# Altium schematic sheet Sensor_Box_PWR_AC.SchDoc
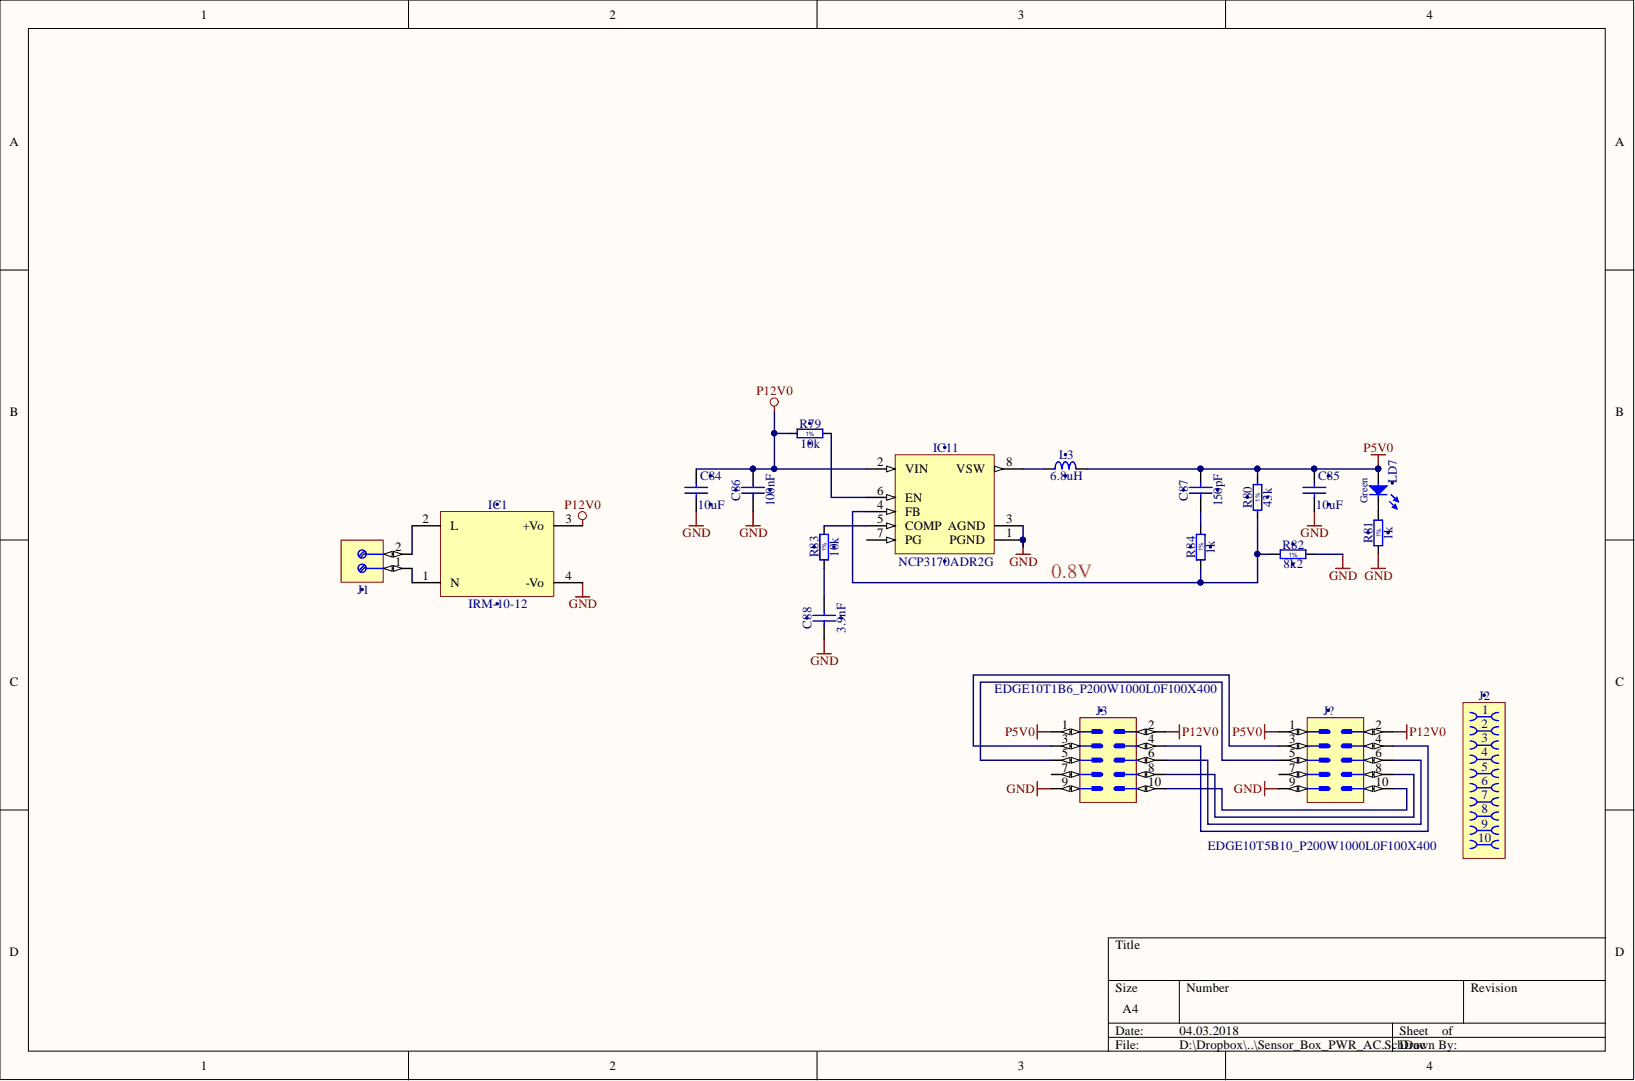
[diagram p0: Sensor_Box_PWR_AC.SchDoc]

## Parts
C84  10uF  [CAPC2012X135N]
C85  10uF  [CAPC2012X135N]
C86  100nF  [CAPC1005X55N]
C87  150pF  [CAPC1608X87N]
C88  3.9nF  [CAPC1608X87N]
IC1  IRM-10-12  [PWS_MEANWELL_IRM-10]
IC11  NCP3170ADR2G  [SOIC127P600X175-8N]
J1  Family  [PHOENIX_1751248]  (value not drawn)
J2  Family  [AVX_00 9159 010 061 916]  (value not drawn)
J3  Family  [EDGE10T1B6_P200W1000L0F100X400]  (value not drawn)  (no BOM)
J?  Family  [EDGE10T5B10_P200W1000L0F100X400]  (value not drawn)  (no BOM)
L3  6.8uH  [IND_BOURNS_SRU8043]
LD7  Green  [LED_KINGBRIGHT_KPTR3216]
R79  10k  [RESC1005X40N]
R80  43k  [RESC1608X55N]
R81  1k  [RESC1005X40N]
R82  8k2  [RESC1608X55N]
R83  10k  [RESC1005X40N]
R84  1k  [RESC1005X40N]

## Nets
GND: C84.2 C85.2 C86.1 C88.1 IC1.4(-Vo) IC11.1(PGND) IC11.3(AGND) J3.9 J?.9 R81.1 R82.2
P5V0: C85.1 C87.2 J3.1 J?.1 L3.2 LD7.2 R80.2
P12V0: C84.1 C86.2 IC1.3(+Vo) IC11.2(VIN) J3.2 J?.2 R79.1
NetC87_1: C87.1 R84.2
NetC88_2: C88.2 R83.1
NetIC1_1: IC1.1(N) J1.1
NetIC1_2: IC1.2(L) J1.2
NetIC11_4: IC11.4(FB) R80.1 R82.1 R84.1
NetIC11_5: IC11.5(COMP) R83.2
NetIC11_6: IC11.6(EN) R79.2
NetIC11_8: IC11.8(VSW) L3.1
NetJ3_3: J3.3 J?.3
NetJ3_4: J3.4 J?.4
NetJ3_5: J3.5 J?.5
NetJ3_6: J3.6 J?.6
NetJ3_8: J3.8 J?.8
NetJ3_10: J3.10 J?.10
NetLD7_1: LD7.1 R81.2

Not connected: IC11 7(PG); J3 7; J? 7

## Library data of the parts
C84 C85 = CC0805_10UF_10V_10%_X7R: Non-polarised capacitor; Manufacturer: GENERIC; Manufacturer1 Part Number: GRM21BR71A106KE51L; Part Description: SMD Multilayer Chip Ceramic Capacitor; Database Table Name: Capacitors SMD; TC: X7R; Tolerance: ±10%; Voltage: 10V; Case: 0805; Component Kind: Standard; Component Type: Standard; CreateDate: 2012-04-30; HelpURL: \\cern.ch\dfs\Applications\Altium\Datasheets\CC0805_X7R_MURATA.pdf; LatestRevisionDate: 2012-04-30; Library Name: Capacitors.DbLib; Manufacturer1 ComponentHeight: 1.35mm; Manufacturer1 Example: MURATA; Manufacturer Part Number: CC0805_10UF_10V_10%_X7R; Mounted: Yes; Part Number: CC0805_10UF_10V_10%_X7R; Pin Count: 2; SMD: Yes; Socket: No; Status: Preferred; Table: Capacitors SMD; Value: 10uF; Author: CERN DEM JLC
C86 = CC0402_100NF_16V_10%_X5R: Non-polarised capacitor; Manufacturer: GENERIC; Manufacturer1 Part Number: GRM155R61C104KA88D; Part Description: SMD Multilayer Chip Ceramic Capacitor; Database Table Name: Capacitors SMD; TC: X5R; Tolerance: ±10%; Voltage: 16V; Case: 0402; Component Kind: Standard; Component Type: Standard; CreateDate: 10.12.2013; HelpURL: \\cern.ch\dfs\Applications\Altium\Datasheets\CC0402_X5R_MURATA_GRM.pdf; LatestRevisionDate: 10.12.2013; Library Name: Capacitors.DbLib; Manufacturer1 ComponentHeight: 0.55mm; Manufacturer1 Example: MURATA; Manufacturer Part Number: CC0402_100NF_16V_10%_X5R; Mounted: Yes; Part Number: CC0402_100NF_16V_10%_X5R; Pin Count: 2; Sense: No; SMD: Yes; Socket: No; Status: None; Value: 100nF; Author: CERN DEM JLC
C87 = CC0603_150PF_50V_1%_NP0: Non-polarised capacitor; Manufacturer: GENERIC; Manufacturer1 Part Number: CC0603FRNPO9BN151; Part Description: SMD Multilayer Chip Ceramic Capacitor; Database Table Name: Capacitors SMD; TC: C0G/NP0; Tolerance: ±1%; Voltage: 50V; Case: 0603; Component Kind: Standard; Component Type: Standard; CreateDate: 17.02.2016; HelpURL: \\cern.ch\dfs\Applications\Altium\Datasheets\CC0805_NP0_PHYCOMP_16VTO50V.pdf; LatestRevisionDate: 17.02.2016; Library Name: Capacitors.DbLib; Manufacturer1 ComponentHeight: 0.7mm; Manufacturer1 Example: PHYCOMP; Manufacturer Part Number: CC0603_150PF_50V_1%_NP0; Mounted: Yes; Part Number: CC0603_150PF_50V_1%_NP0; Pin Count: 2; Sense: No; SMD: Yes; Socket: No; Status: None; Value: 150pF; Author: CERN DEM MR
C88 = CC0603_3.9NF_50V_5%_X7R: Non-polarised capacitor; Manufacturer: GENERIC; Manufacturer1 Part Number: 223858615631; Part Description: SMD Multilayer Chip Ceramic Capacitor; Database Table Name: Capacitors SMD; TC: X7R; Tolerance: ±5%; Voltage: 50V; Case: 0603; Component Kind: Standard; Component Type: Standard; CreateDate: 03.12.2007; HelpURL: \\cern.ch\dfs\Applications\Altium\Datasheets\CC0603_X7R_PHYCOMP.pdf; LatestRevisionDate: 03.12.2007; Library Name: Capacitors.DbLib; Manufacturer1 ComponentHeight: 0.87mm; Manufacturer1 Example: PHYCOMP; Manufacturer Part Number: CC0603_3.9NF_50V_5%_X7R; Mounted: Yes; Part Number: CC0603_3.9NF_50V_5%_X7R; Pin Count: 2; Sense: No; SMD: Yes; Socket: No; Status: Preferred; Value: 3.9nF; Author: CERN DEM JLC
IC1 = IRM-10-12: Power Supply; Manufacturer: MEAN WELL; Part Description: 85-264VAC To 12VDC (850mA) 10.2W Power Supply; Bonding: No; Database Table Name: Power Supplies; PressFit: No; ComponentHeight: 21.5mm; Component Kind: Standard; Component Type: Standard; CreateDate: 14.02.2018; Device: IRM-10-12; HelpURL: \\cern.ch\dfs\Applications\Altium\Datasheets\IRM-10-12.pdf; LatestRevisionDate: 14.02.2018; Library Name: ICs And Semiconductors.DbLib; Manufacturer Part Number: IRM-10-12; Mounted: Yes; PackageDescription: IRM-10 Series, Body 45.7x25.4mm, Power Supply; Part Number: IRM-10-12; Pin Count: 4; Sense: No; SMD: No; Socket: No; Status: None; Author: CERN DEM MR
IC11 = NCP3170ADR2G: Synchronous PWM Switching Converter; Manufacturer: ON SEMICONDUCTOR; Bonding: No; Database Table Name: Analog & Interface; PressFit: No; Case: SOIC8; ComponentHeight: 1.75mm; Component Kind: Standard; Component Type: Standard; CreateDate: 30.05.2016; Device: NCP3170ADR2G; HelpURL: \\cern.ch\dfs\Applications\Altium\Datasheets\NCP3170ADR2G.pdf; LatestRevisionDate: 30.05.2016; Library Name: ICs And Semiconductors.DbLib; Manufacturer Part Number: NCP3170ADR2G; Mounted: Yes; PackageDescription: SOIC 8, Pitch 1.27mm - Body 4x5mm, IPC Medium Density; Part Description: Synchronous PWM Switching Converter; Part Number: NCP3170ADR2G; Pin Count: 8; Sense: No; SMD: Yes; Socket: No; Author: CERN DEM MR
J1 = PHOENIX_1751248: Terminal Block, 2 Positions, Screw Connection; Manufacturer: PHOENIX CONTACT; Manufacturer Part Number: 1751248 (MKDS 1/ 2-3,5); Part Description: 10A 160V, Terminal Block, Pitch 3.5mm, 2 Positions, Screw Connection; PressFit: No; ComponentHeight: 8.5mm; Component Kind: Standard; Component Type: Standard; CreateDate: 03.11.2009; Database Table Name: PHOENIX; HelpURL: \\cern.ch\dfs\Applications\Altium\Datasheets\PHOENIX_1751248.pdf; LatestRevisionDate: 26.04.2010; Library Name: Connectors.DbLib; Mounted: Yes; Part Number: PHOENIX_1751248; Pin Count: 2; Sense: No; SMD: No; Socket: No; Status: None; Author: CERN DEM JLC
J2 = AVX_00 9159 010 061 916: Open Ended Card Edge Connector, 9159 Series; Manufacturer: AVX; Part Description: 10 Contacts, 2mm Pitch, Open Ended Card Edge Connector, 9159 Series; Database Table Name: Miscellaneous; PressFit: No; ComponentHeight: 1.6mm; Component Kind: Standard; Component Type: Standard; CreateDate: 13.02.2018; HelpURL: \\cern.ch\dfs\Applications\Altium\Datasheets\AVX_00 9159 010 061 916.pdf; LatestRevisionDate: 13.02.2018; Library Name: Connectors.DbLib; Manufacturer Part Number: 00 9159 010 061 916; Mounted: Yes; Part Number: AVX_00 9159 010 061 916; Pin Count: 10; Sense: No; SMD: No; Socket: No; Status: None; Author: CERN DEM MR
J3 = EDGE10T1B6_P200W1000L0F100X400: PCB Edge Connector 10 (2x5); Part Description: 10 Contacts Board Edge Connector, Pitch 2mm, Length 0mm, Width 10mm, Finger Lenght 4mm, Finger Width 1mm; Database Table Name: Miscellaneous; Mounted: No; PressFit: No; ComponentHeight: 0mm; Component Type: Standard (No BOM); CreateDate: 14.02.2018; HelpURL: \\cern.ch\dfs\Applications\Altium\Datasheets\EDGE10T1B6_P200W1000L0F100X400.pdf; LatestRevisionDate: 14.02.2018; Library Name: Connectors.DbLib; Part Number: EDGE10T1B6_P200W1000L0F100X400; Pin Count: 10; Sense: No; SMD: No; Socket: No; Status: None; Author: CERN DEM MR
J? = EDGE10T5B10_P200W1000L0F100X400: PCB Edge Connector 10 (2x5); Part Description: 10 Contacts Board Edge Connector, Pitch 2mm, Length 0mm, Width 10mm, Finger Lenght 4mm, Finger Width 1mm; Database Table Name: Miscellaneous; Mounted: No; PressFit: No; ComponentHeight: 0mm; Component Type: Standard (No BOM); CreateDate: 14.02.2018; HelpURL: \\cern.ch\dfs\Applications\Altium\Datasheets\EDGE10T5B10_P200W1000L0F100X400.pdf; LatestRevisionDate: 14.02.2018; Library Name: Connectors.DbLib; Part Number: EDGE10T5B10_P200W1000L0F100X400; Pin Count: 10; Sense: No; SMD: No; Socket: No; Status: None; Author: CERN DEM MR
L3 = IND_6.8UH_30%_BOURNS_SRU8043: Inductor; Manufacturer: BOURNS; Manufacturer Part Number: SRU8043-6R8Y; Part Description: Shielded SMD Power Inductor; Database Table Name: Inductors SMD; Power: 3.8A; Resistance: 0.0224R; Tolerance: ±30%; ComponentHeight: 4.6mm; Component Kind: Standard; Component Type: Standard; CreateDate: 06.08.2015; HelpURL: \\cern.ch\dfs\Applications\Altium\Datasheets\IND_BOURNS_SRU8043.pdf; LatestRevisionDate: 06.08.2015; Library Name: Inductors & Transformers.DbLib; Mounted: Yes; Part Number: IND_6.8UH_30%_BOURNS_SRU8043; Pin Count: 2; Sense: No; SMD: Yes; Socket: No; Status: None; Value: 6.8uH; Author: CERN DEM JMW
LD7 = LED_KINGBRIGHT_KPTR-3216CGCK: LED Green 1A 2C; Part Description: Reverse Mount LED 1.4x1.6mm Green; Bonding: No; Database Table Name: LEDs & Displays; PressFit: No; Color: Green; ComponentHeight: 0.35mm; Component Kind: Standard; Component Type: Standard; CreateDate: 15.02.2016; HelpURL: \\cern.ch\dfs\Applications\Altium\Datasheets\LED_KINGBRIGHT_KPTR-3216CGCK.pdf; LatestRevisionDate: 15.02.2016; Library Name: ICs And Semiconductors.DbLib; Manufacturer: KINGBRIGHT; Manufacturer Part Number: KPTR-3216CGCK; Mounted: Yes; PackageDescription: Reverse Mount LED 3216, Hole 2.1x2.3mm 0.35mm Height; Part Number: LED_KINGBRIGHT_KPTR-3216CGCK; Pin Count: 2; Sense: No; SMD: Yes; Socket: No; Author: CERN DEM MR
R79 R83 = R0402_10K_1%_0.0625W_100PPM: Resistor - 1%; Manufacturer: GENERIC; Manufacturer1 Part Number: 232270671003L; Part Description: General Purpose Thick Film Chip Resistor; Database Table Name: Resistors SMD; PressFit: No; TC: ±100ppm/°C; Case: 0402; Component Kind: Standard; Component Type: Standard; CreateDate: 03.12.2007; HelpURL: \\cern.ch\dfs\Applications\Altium\Datasheets\R0402_Phycomp_RC0402.pdf; LatestRevisionDate: 17.10.2012; Library Name: Resistors.DbLib; Manufacturer1 ComponentHeight: 0.4mm; Manufacturer1 Example: YAGEO PHYCOMP; Manufacturer Part Number: R0402_10K_1%_0.0625W_100PPM; Mounted: Yes; Part Number: R0402_10K_1%_0.0625W_100PPM; Pin Count: 2; Power: 0.0625W; Sense: No; SMD: Yes; Socket: No; Status: Not Recommended; Tolerance: ±1%; Value: 10k; Author: CERN DEM JLC
R80 = R0603_43K_1%_0.1W_100PPM: Resistor - 1%; Manufacturer: GENERIC; Manufacturer1 Part Number: NRC06F4302TRF; Part Description: General Purpose Thick Film Chip Resistor; Database Table Name: Resistors SMD; Power: 0.1W; PressFit: No; TC: ±100ppm/°C; Case: 0603; Component Kind: Standard; Component Type: Standard; CreateDate: 03.12.2007; HelpURL: \\cern.ch\dfs\Applications\Altium\Datasheets\R0603_NIC_NRC.pdf; LatestRevisionDate: 13.03.2008; Library Name: Resistors.DbLib; Manufacturer1 ComponentHeight: 0.55mm; Manufacturer1 Example: NIC COMPONENT; Manufacturer Part Number: R0603_43K_1%_0.1W_100PPM; Mounted: Yes; Part Number: R0603_43K_1%_0.1W_100PPM; Pin Count: 2; Sense: No; SMD: Yes; Socket: No; Status: Preferred; Tolerance: ±1%; Value: 43k; Author: CERN DEM JLC
R81 R84 = R0402_1K_1%_0.0625W_100PPM: Resistor - 1%; Manufacturer: GENERIC; Manufacturer1 Part Number: 232270671002L; Part Description: General Purpose Thick Film Chip Resistor; Database Table Name: Resistors SMD; PressFit: No; TC: ±100ppm/°C; Case: 0402; Component Kind: Standard; Component Type: Standard; CreateDate: 03.12.2007; HelpURL: \\cern.ch\dfs\Applications\Altium\Datasheets\R0402_Phycomp_RC0402.pdf; LatestRevisionDate: 17.10.2012; Library Name: Resistors.DbLib; Manufacturer1 ComponentHeight: 0.4mm; Manufacturer1 Example: YAGEO PHYCOMP; Manufacturer Part Number: R0402_1K_1%_0.0625W_100PPM; Mounted: Yes; Part Number: R0402_1K_1%_0.0625W_100PPM; Pin Count: 2; Power: 0.0625W; Sense: No; SMD: Yes; Socket: No; Status: Not Recommended; Tolerance: ±1%; Value: 1k; Author: CERN DEM JLC
R82 = R0603_8K2_1%_0.1W_100PPM: Resistor - 1%; Manufacturer: GENERIC; Manufacturer1 Part Number: NRC06F8201TRF; Part Description: General Purpose Thick Film Chip Resistor; Database Table Name: Resistors SMD; Power: 0.1W; PressFit: No; TC: ±100ppm/°C; Case: 0603; Component Kind: Standard; Component Type: Standard; CreateDate: 03.12.2007; HelpURL: \\cern.ch\dfs\Applications\Altium\Datasheets\R0603_NIC_NRC.pdf; LatestRevisionDate: 13.03.2008; Library Name: Resistors.DbLib; Manufacturer1 ComponentHeight: 0.55mm; Manufacturer1 Example: NIC COMPONENT; Manufacturer Part Number: R0603_8K2_1%_0.1W_100PPM; Mounted: Yes; Part Number: R0603_8K2_1%_0.1W_100PPM; Pin Count: 2; Sense: No; SMD: Yes; Socket: No; Status: Preferred; Tolerance: ±1%; Value: 8k2; Author: CERN DEM JLC
